# Altium schematic sheet Sheet1.SchDoc
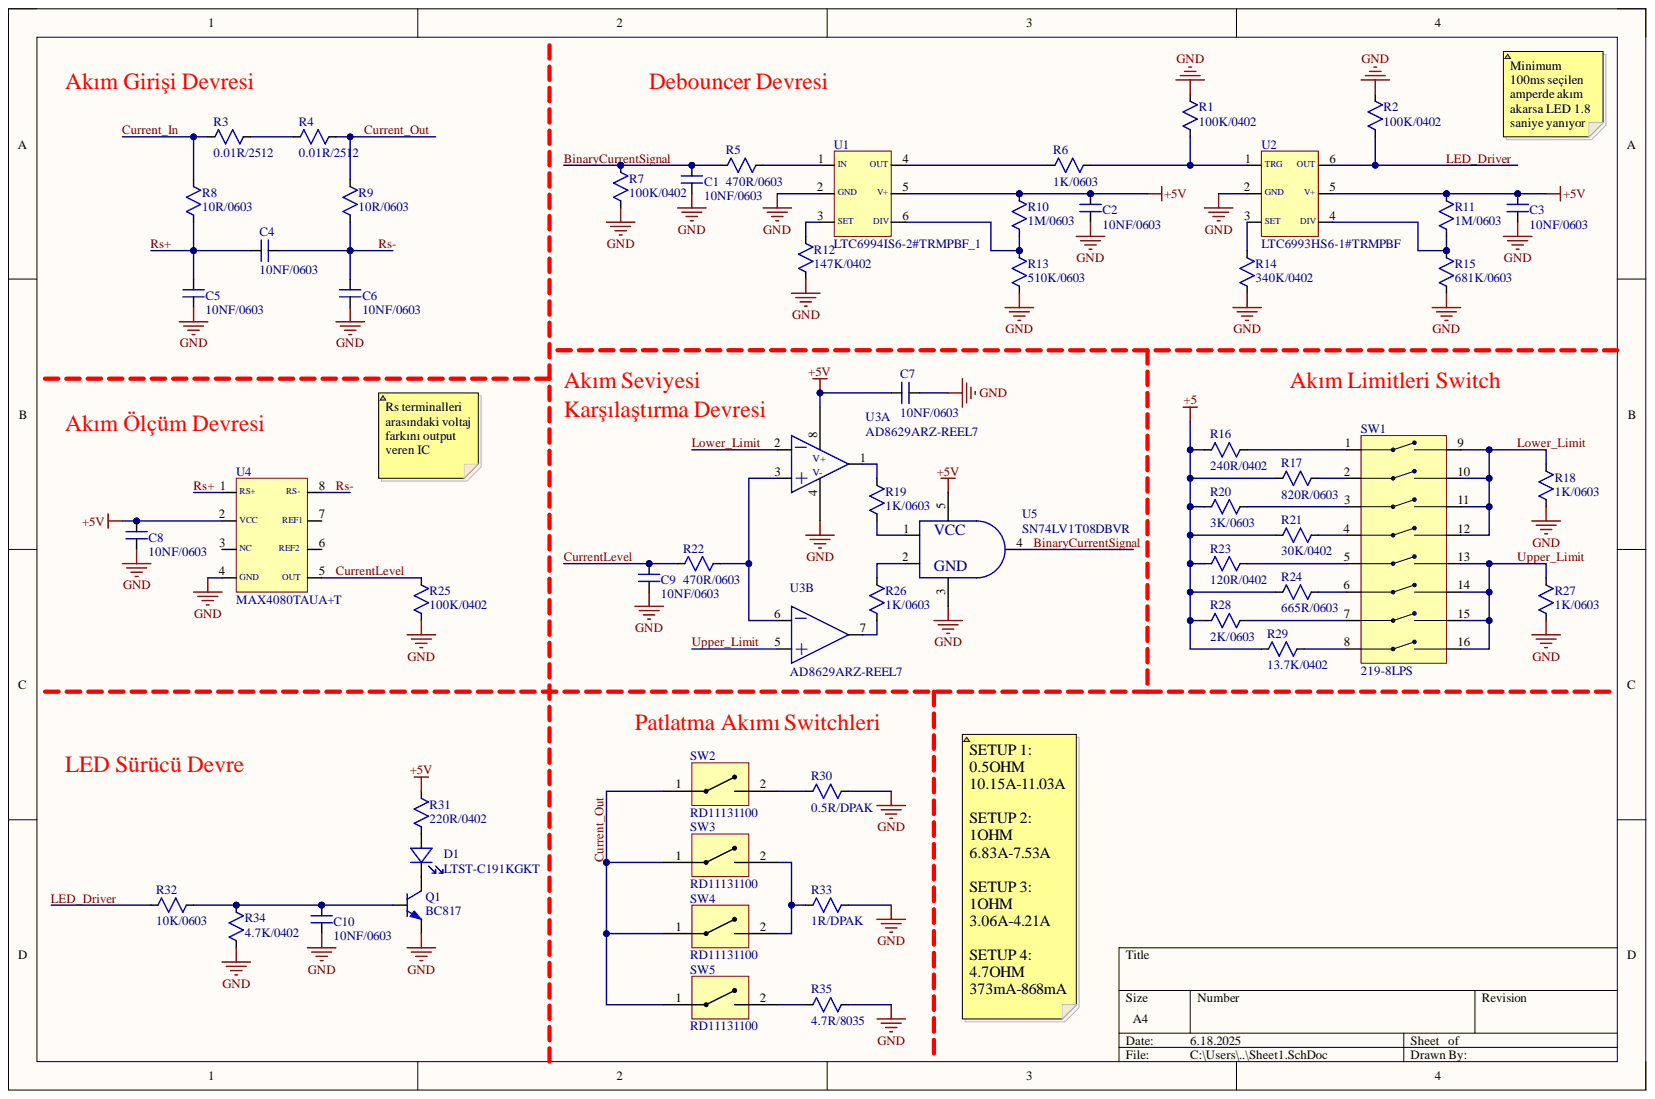
[diagram p0: Sheet1.SchDoc]

## Parts
C1  10NF/0603
C2  10NF/0603
C3  10NF/0603
C4  10NF/0603
C5  10NF/0603
C6  10NF/0603
C7  10NF/0603
C8  10NF/0603
C9  10NF/0603
C10  10NF/0603
D1  LTST-C191KGKT
Q1  BC817
R1  100K/0402
R2  100K/0402
R3  0.01R/2512
R4  0.01R/2512
R5  470R/0603
R6  1K/0603
R7  100K/0402
R8  10R/0603
R9  10R/0603
R10  1M/0603
R11  1M/0603
R12  147K/0402
R13  510K/0603
R14  340K/0402
R15  681K/0603
R16  240R/0402
R17  820R/0603
R18  1K/0603
R19  1K/0603
R20  3K/0603
R21  30K/0402
R22  470R/0603
R23  120R/0402
R24  665R/0603
R25  100K/0402
R26  1K/0603
R27  1K/0603
R28  2K/0603
R29  13.7K/0402
R30  0.5R/DPAK
R31  220R/0402
R32  10K/0603
R33  1R/DPAK
R34  4.7K/0402
R35  4.7R/8035
SW1  219-8LPS
SW2  RD11131100
SW3  RD11131100
SW4  RD11131100
SW5  RD11131100
U1  LTC6994IS6-2#TRMPBF_1
U2  LTC6993HS6-1#TRMPBF
U3  AD8629ARZ-REEL7  (parts A,B)
U4  MAX4080TAUA+T
U5  SN74LV1T08DBVR

## Nets
+5: R16.1 R17.1 R20.1 R21.0 R23.0 R24.0 R28.0 R29.0
+5V: C2.2 C3.2 C7.1 C8.2 R10.1 R11.1 R31.1 U1.5(V+) U2.5(V+) U3.8(V+) U4.2(VCC) U5.5
GND: C1.1 C2.1 C3.1 C5.1 C6.1 C7.2 C8.1 C9.1 C10.1 Q1.E R1.1 R2.1 R7.0 R12.0 R13.0 R14.0 R15.0 R18.0 R25.0 R27.0 R30.1 R33.1 R34.0 R35.1 U1.2(GND) U2.2(GND) U3.4(V-) U4.4(GND) U5.3
BinaryCurrentSignal: C1.2 R5.0 R7.1 U5.4
Current_In: R3.0 R8.1
Current_Out: R4.1 R9.1 SW2.1 SW3.1 SW4.1 SW5.1
CurrentLevel: C9.2 R22.0 R25.1 U4.5(OUT)
LED_Driver: R2.0 R32.1 U2.6(OUT)
Lower_Limit: R18.1 SW1.9 SW1.10 SW1.11 SW1.12 U3.2
Rs+: C4.1 C5.2 R8.0 U4.1(RS+)
Rs-: C4.2 C6.2 R9.0 U4.8(RS-)
Upper_Limit: R27.1 SW1.13 SW1.14 SW1.15 SW1.16 U3.5(+)
NetC10_2: C10.2 Q1.B R32.0 R34.1
NetD1_1: D1.1 R31.0
NetD1_2: D1.2 Q1.C
NetR1_0: R1.0 R6.1 U2.1(TRG)
NetR3_1: R3.1 R4.0
NetR5_1: R5.1 U1.1(IN)
NetR6_0: R6.0 U1.4(OUT)
NetR10_0: R10.0 R13.1 U1.6(DIV)
NetR11_0: R11.0 R15.1 U2.4(DIV)
NetR12_1: R12.1 U1.3(SET)
NetR14_1: R14.1 U2.3(SET)
NetR16_0: R16.0 SW1.1
NetR17_0: R17.0 SW1.2
NetR19_0: R19.0 U5.1(VCC)
NetR19_1: R19.1 U3.1
NetR20_0: R20.0 SW1.3
NetR21_1: R21.1 SW1.4
NetR22_1: R22.1 U3.3(+) U3.6
NetR23_1: R23.1 SW1.5
NetR24_1: R24.1 SW1.6
NetR26_0: R26.0 U3.7
NetR26_1: R26.1 U5.2(GND)
NetR28_1: R28.1 SW1.7
NetR29_1: R29.1 SW1.8
NetR30_0: R30.0 SW2.2
NetR33_0: R33.0 SW3.2 SW4.2
NetR35_0: R35.0 SW5.2

Not connected: U4 3(NC) 6(REF2) 7(REF1)

## Library data of the parts
C1-C10 = CC0603KPX7R9BB103: CAP CER 10000PF 50V X7R 0603; Component Kind: Standard; Library Name: PA_Test_Kartı_v2.SchLib
D1 = LTST-C191KGKT: LED GREEN CLEAR SMD; Component Kind: Standard; Library Name: PA_Test_Kartı_v2.SchLib
Q1 = BC817-40,215: TRANS NPN 45V 0.5A TO236AB; Component Kind: Standard; Library Name: PA_Test_Kartı_v2.SchLib
R1 R2 R7 R25 = RC0402FR-07100KL: RES SMD 100 KOHM 1% 1/16W 0402; Component Kind: Standard; Library Name: PA_Test_Kartı_v2.SchLib
R3 R4 = GMR100HTBFA10L0: RES SMD  0.01 OHM CURR SENSE 7W 1% 2512; Component Kind: Standard; Library Name: PA_Test_Kartı_v2.SchLib
R5 R22 = RC0603JR-07470RL: RES SMD 470 OHM 5% 1/10W 0603; Component Kind: Standard; Library Name: PA_Test_Kartı_v2.SchLib
R6 R18 R19 R26 R27 = RT0603BRD131KL: RES SMD 1K OHM 0.1% 1/10W 0603; Component Kind: Standard; Library Name: PA_Test_Kartı_v2.SchLib
R8 R9 = RT0603BRD0710RL: RES SMD 10 OHM 0.1% 1/10W 0603; Component Kind: Standard; Library Name: PA_Test_Kartı_v2.SchLib
R10 R11 = ERJ-3EKF1004V: RES SMD 1M OHM 1% 1/10W 0603; Component Kind: Standard; Library Name: PA_Test_Kartı_v2.SchLib
R12 = RC0402FR-07147KL: RES SMD 147K OHM 1% 1/16W 0402; Component Kind: Standard; Library Name: PA_Test_Kartı_v2.SchLib
R13 = RC0603FR-07510KL: RES SMD 510K OHM 1% 1/10W 0603; Component Kind: Standard; Library Name: PA_Test_Kartı_v2.SchLib
R14 = ERJ-2RKF3403X: RES SMD 340K OHM 1% 1/10W 0402; Component Kind: Standard; Library Name: PA_Test_Kartı_v2.SchLib
R15 = RMCF0603FT681K: RES  681K OHM 1% 1/10W 0603; Component Kind: Standard; Library Name: PA_Test_Kartı_v2.SchLib
R16 = ERJ-2RKF2400X: RES SMD 240 OHM 1% 1/10W 0402; Component Kind: Standard; Library Name: PA_Test_Kartı_v2.SchLib
R17 = RC0603FR-07820LR: RES SMD 820 OHM 1% 1/10W 0603; Component Kind: Standard; Library Name: PA_Test_Kartı_v2.SchLib
R20 = ERJ-PA3J302V: RES SMD 3K OHM 5% 1/3W 0603; Component Kind: Standard; Library Name: PA_Test_Kartı_v2.SchLib
R21 = ERJ-2RKF3002X: RES SMD 30K OHM 1% 1/10W 0402; Component Kind: Standard; Library Name: PA_Test_Kartı_v2.SchLib
R23 = ERJ-2RKF1200X: RES SMD 120 OHM 1% 1/10W 0402; Component Kind: Standard; Library Name: PA_Test_Kartı_v2.SchLib
R24 = RC0603FR-07665RL: RES SMD 665 OHM 1% 1/10W 0603; Component Kind: Standard; Library Name: PA_Test_Kartı_v2.SchLib
R28 = ERA-3AEB202V: RES SMD 2K OHM 0.1% 1/10W 0603; Component Kind: Standard; Library Name: PA_Test_Kartı_v2.SchLib
R29 = ERJ-2RKF1372X: RES SMD 13.7K OHM 1% 1/10W 0402; Component Kind: Standard; Library Name: PA_Test_Kartı_v2.SchLib
R30 = PWR163S-25-R500FE: RES POWER 0.5 OHM  25W 1% Non-Standart DPAK; Component Kind: Standard; Library Name: PA_Test_Kartı_v2.SchLib
R31 = ERJ-2RKF2200X: RES SMD 220 OHM 1% 1/10W 0402; Component Kind: Standard; Library Name: PA_Test_Kartı_v2.SchLib
R32 = RT0603BRD0710KL: RES SMD 10K OHM 0.1% 1/10W 0603; Component Kind: Standard; Library Name: PA_Test_Kartı_v2.SchLib
R33 = PWR163S-25-1R00FE: RES POWER  1 OHM  25W 1% Non-Standart DPAK; Component Kind: Standard; Library Name: PA_Test_Kartı_v2.SchLib
R34 = RC0402JR-074K7L: RES SMD 4.7K OHM 5% 1/16W 0402; Component Kind: Standard; Library Name: PA_Test_Kartı_v2.SchLib
R35 = SM8035JT4R70: RES POWER 4.7 OHM  4W 5% 8035 J-Lead; Component Kind: Standard; Library Name: PA_Test_Kartı_v2.SchLib
SW1 = 219-8LPS: SWITCH 100mA, 20 VDC, DIP 8 SPST IC; Component Kind: Standard; Library Name: PA_Test_Kartı_v2.SchLib
SW2-SW5 = RD11131100: POWER SWITCH 16A, 125 VAC, ROCKER SPST; Component Kind: Standard; Library Name: PA_Test_Kartı_v2.SchLib
U3 = AD8629ARZ-REEL7: IC OPAMP ZERO-DRIFT 2 CIRC 8SOIC; Component Kind: Standard; Library Name: PA_Test_Kartı_v2.SchLib
U5 = SN74LV1T08DBVR: 1CH 2INP AND-Gate 5V; Component Kind: Standard; Library Name: PA_Test_Kartı_v2.SchLib
U1 = LTC6994IS6-2#TRMPBF_1: Component Kind: Standard; Library Name: PA_Test_Kartı_v2.SchLib
U2 = LTC6993HS6-1#TRMPBF: Component Kind: Standard; Library Name: PA_Test_Kartı_v2.SchLib
U4 = MAX4080TAUA+T: Component Kind: Standard; Library Name: PA_Test_Kartı_v2.SchLib
